# Altium schematic sheet Battery Pack_P2_REV_1.SchDoc
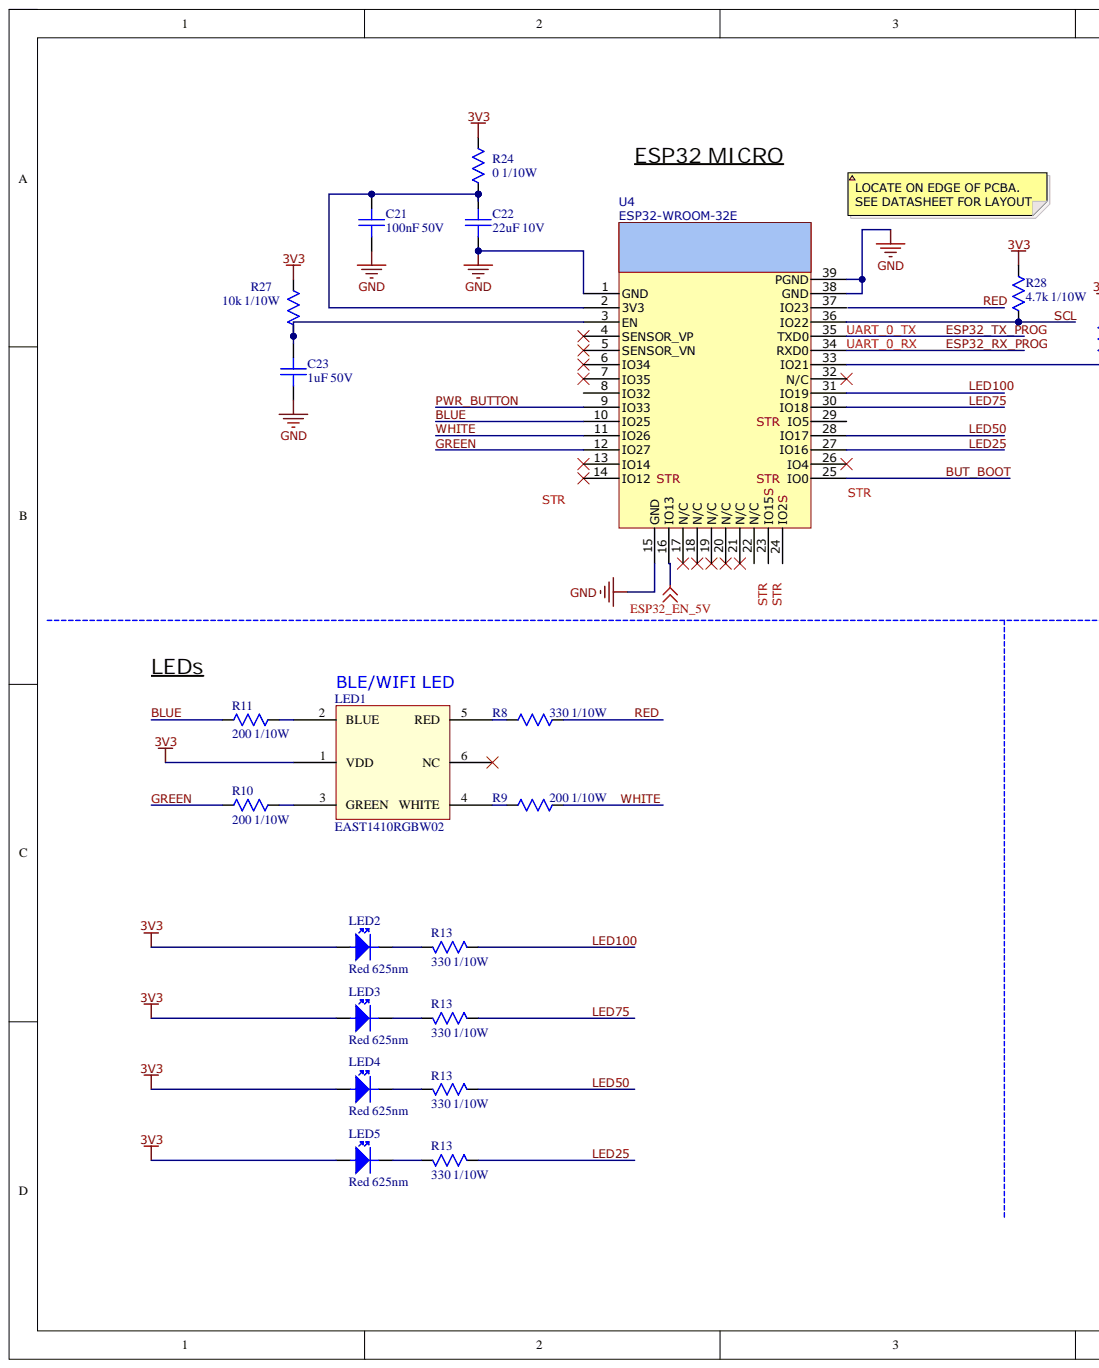
[diagram p0: Battery Pack_P2_REV_1.SchDoc, left]
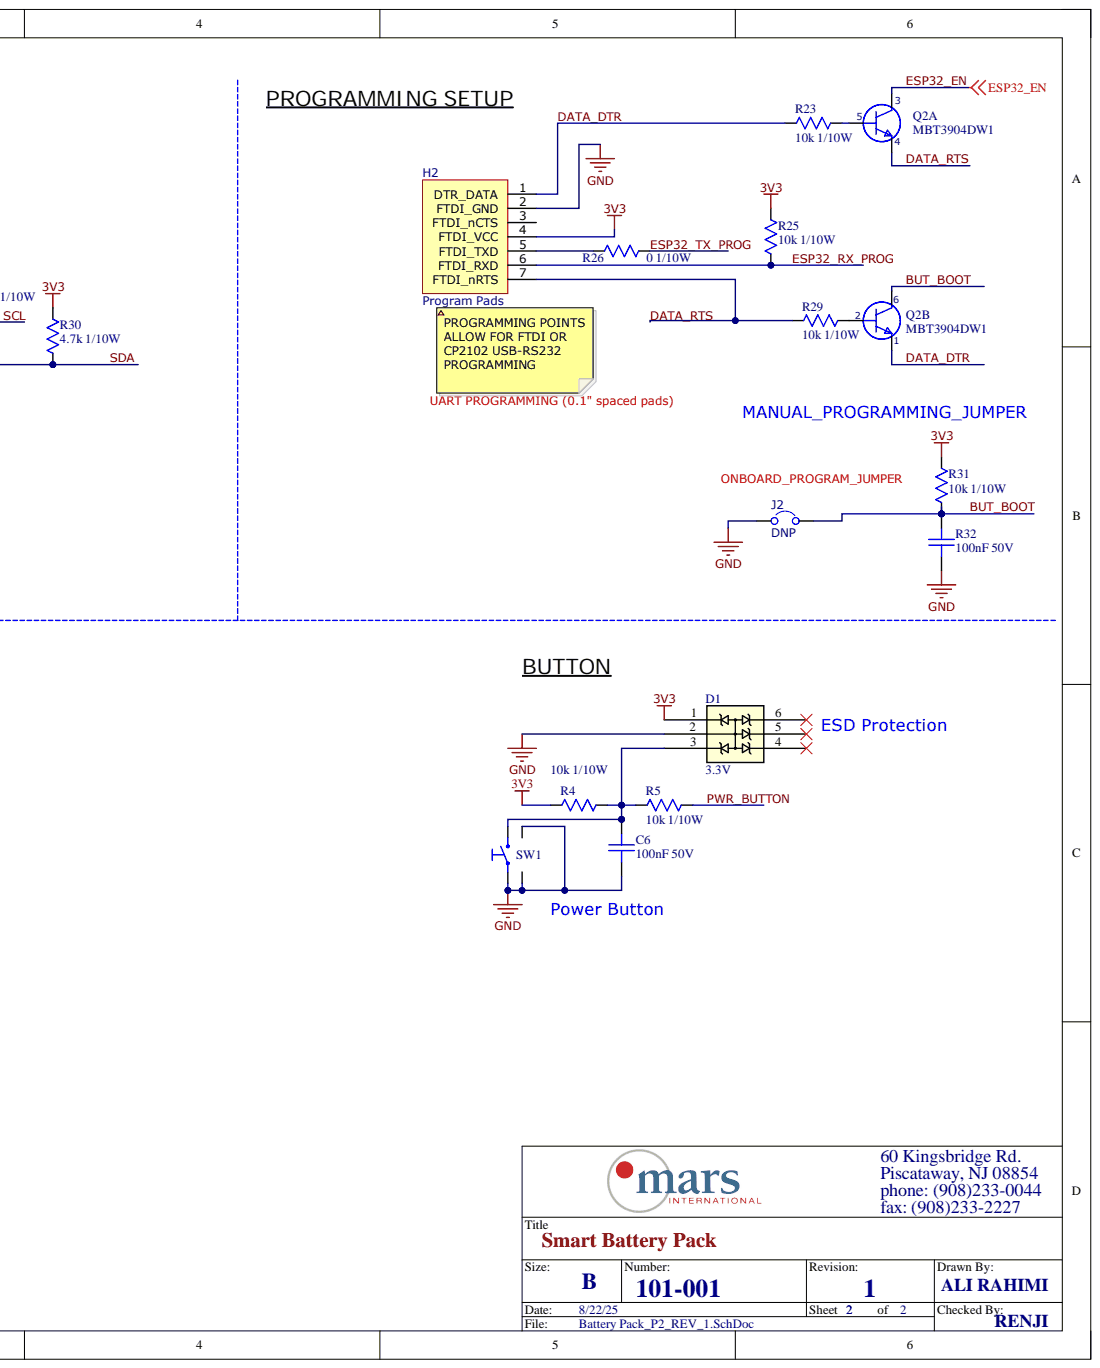
[diagram p1: Battery Pack_P2_REV_1.SchDoc, right]

## Parts
C6  100nF 50V  [IPC-0603]
C21  100nF 50V  [IPC-0603]
C22  22uF 10V  Voltage Rating: 10V  [IPC-1206]
C23  1uF 50V  Voltage Rating: 50V  [IPC-0603-Small]
D1  3.3V  [SOT363-SMALL-2NPN-123456]
H2  Program Pads  [PROGRAM-PADS-7-STRAIGHT]
J2  DNP  [CON-2]
LED1  EAST1410RGBW02  [EAST1410RGBW02]
LED2  Red 625nm  Color: Red  Wavelength: 625nm  [0603_diode]
LED3  Red 625nm  Color: Red  Wavelength: 625nm  [0603_diode]
LED4  Red 625nm  Color: Red  Wavelength: 625nm  [0603_diode]
LED5  Red 625nm  Color: Red  Wavelength: 625nm  [0603_diode]
Q2  MBT3904DW1  [SOT363-SMALL-2NPN]  (parts A,B)
R4  10k 1/10W  [IPC-0603]
R5  10k 1/10W  [IPC-0603]
R8  330 1/10W  [IPC-0603]
R9  200 1/10W  [IPC-0603]
R10  200 1/10W  [IPC-0603]
R11  200 1/10W  [IPC-0603]
R13  330 1/10W  [IPC-0603]
R13  330 1/10W  [IPC-0603]
R13  330 1/10W  [IPC-0603]
R13  330 1/10W  [IPC-0603]
R23  10k 1/10W  [IPC-0603]
R24  0 1/10W  [IPC-0603]
R25  10k 1/10W  [IPC-0603]
R26  0 1/10W  [IPC-0603]
R27  10k 1/10W  [IPC-0603]
R28  4.7k 1/10W  [IPC-0603]
R29  10k 1/10W  [IPC-0603]
R30  4.7k 1/10W  [IPC-0603]
R31  10k 1/10W  [IPC-0603]
R32  100nF 50V  [IPC-0603]
SW1  CHANNEL  [ESWITCH_TL1105VF]  (value not drawn)
U4  ESP32-WROOM-32E  [ESP32WROOM32E]

## Nets
3V3: D1.1 H2.4(FTDI_VCC) LED1.1(VDD) LED2.A LED3.A LED4.A LED5.A R4.1 R24.2 R25.2 R27.2 R28.2 R30.2 R31.2
ESP32_EN_5V: U4.16(IO13)
GND: C6.1 C21.1 C22.1 C23.1 D1.2 H2.2(FTDI_GND) J2.1 R32.1 SW1.1 SW1.3 SW1.4 U4.1(GND) U4.15(GND) U4.38(GND) U4.39(PGND)
BLUE: R11.1 U4.10(IO25)
BUT_BOOT: J2.2 Q2.6 R31.1 R32.2 U4.25(IO0)
DATA_DTR: H2.1(DTR_DATA) Q2.1 R23.2
DATA_RTS: H2.7(FTDI_nRTS) Q2.4 R29.2
ESP32_EN: Q2.3
ESP32_RX_PROG: H2.6(FTDI_RXD) R25.1 U4.34(RXD0)
ESP32_TX_PROG: R26.1 U4.35(TXD0)
GREEN: R10.1 U4.12(IO27)
LED25: R13.1 U4.27(IO16)
LED50: R13.1 U4.28(IO17)
LED75: R13.1 U4.30(IO18)
LED100: R13.1 U4.31(IO19)
PWR_BUTTON: R5.2 U4.9(IO33)
RED: R8.2
SCL: R28.1 U4.36(IO22)
SDA: R30.1 U4.33(IO21)
WHITE: R9.2 U4.11(IO26)
NetC6_2: C6.2 D1.3 R4.2 R5.1 SW1.2
NetC21_2: C21.2 C22.2 R24.1 U4.2(3V3)
NetC23_2: C23.2 R27.1 U4.3(EN)
NetH2_5: H2.5(FTDI_TXD) R26.2
NetLED1_2: LED1.2(BLUE) R11.2
NetLED1_3: LED1.3(GREEN) R10.2
NetLED1_4: LED1.4(WHITE) R9.1
NetLED1_5: LED1.5(RED) R8.1
NetLED2_K: LED2.K R13.2
NetLED3_K: LED3.K R13.2
NetLED4_K: LED4.K R13.2
NetLED5_K: LED5.K R13.2
NetQ2_2: Q2.2 R29.1
NetQ2_5: Q2.5 R23.1

Not connected: D1 4 5 6; H2 3(FTDI_nCTS); LED1 6(NC); U4 4(SENSOR_VP) 5(SENSOR_VN) 6(IO34) 7(IO35) 8(IO32) 13(IO14) 14(IO12) 17(N/C) 18(N/C) 19(N/C) 20(N/C) 21(N/C) 22(N/C) 23(IO15_S) 24(IO2_S) 26(IO4) 29(IO5) 32(N/C) 37(IO23)

## Library data of the parts
C6 = SC-0201-01: CAP CER 0.1uF 50V X7R 0603; AVL Part Numbers: GRM188R71H104KA93D, CL10B104KB8NNNC, 0603B104K500BD, CC0603KRX7R9BB104, C0603T104K5RALTU, C1608X7R104KGTS, 06035C104KAT2A; Manufacturer: AVX; Manufacturer Part Number: 06035C104KAT2A; Approved: Yes; AVL Vendors: Murata, Samsung, Team Young, Yageo, Kemet , Darfon, AVX; Database Table Name: Capacitors - Surface Mount; Dielectric Material: Ceramic; ESR: NA; Tolerance: 10%; Case/Package: 0603; Component Kind: Standard; Dielectric: X7R; Height: 0.035"; Length: 0.063"; Life(Hours): NA; Max Operating Temperature: 125°C; Min Operating Temperature: -55°C; PT Number: SC-0201-01; Ripple Current: NA; Supplier 1: Mouser; Supplier Part Number 1: 581-06035C104KAT2A; Voltage Rating: 50V; Width: 0.032"; Approved By: ADMIN; Created By: ADMIN; Library Name: MarsLibrary_Test_Environment.DbLib
C21 R32 = SC-0201-01: CAP CER 0.1uF 50V X7R 0603; AVL Part Numbers: GRM188R71H104KA93D, CL10B104KB8NNNC, 0603B104K500BD, CC0603KRX7R9BB104, C0603T104K5RALTU, C1608X7R104KGTS; Manufacturer: AVX; Manufacturer Part Number: 06035C104KAT2A; Approved: Yes; AVL Vendors: Murata, Samsung, Team Young, Yageo, Kemet , Darfon; Database Table Name: Capacitors - Surface Mount; Dielectric Material: Ceramic; ESR: NA; Tolerance: 10%; Case/Package: 0603; Component Kind: Standard; Dielectric: X7R; Height: 0.035"; Length: 0.063"; Life(Hours): NA; Max Operating Temperature: 125°C; Min Operating Temperature: -55°C; PT Number: SC-0201-01; Ripple Current: NA; Supplier 1: Mouser; Supplier Part Number 1: 581-06035C104KAT2A; Voltage Rating: 50V; Width: 0.032"; Approved By: ADMIN; Created By: ADMIN; Library Name: MarsLibrary_Test_Environment.DbLib
C22 = SC-0627-01: Cap Ceramic 22uF 10V X7R 10% SMD 1206 125C Embossed T/R; AVL Part Numbers: CL31B226KPHNNNE; Approved: Yes; AVL Vendors: Samsung; Database Table Name: Capacitors - Surface Mount; ESR: NA; Case/Package: 1206; Component Kind: Standard; Dielectric: X7R; Dielectric Material: Ceramic; Height: 0.071"; Length: 0.126"; Life(Hours): NA; Manufacturer: Samsung; Manufacturer Part Number: CL31B226KPHNNNE; Max Operating Temperature: 125°C; Min Operating Temperature: -55°C; PT Number: SC-0627-01; Ripple Current: NA; Supplier 1: Digi-Key; Supplier Part Number 1: 1276-2769-1-ND; Tolerance: 10%; Width: 0.063"; Approved By: ADMIN; Created By: ADMIN; Library Name: MarsLibrary_Test_Environment.DbLib
C23 = SC-0786-01: CAP CER 1UF 50V X5R 0603; AVL Part Numbers: UMK107BJ105KA-T, CL10A105KB8NNNC; Manufacturer: Taiyo Yuden; Manufacturer Part Number: UMK107BJ105KA-T; Approved: Yes; AVL Vendors: Taiyo Yuden, Samsung; Database Table Name: Capacitors - Surface Mount; Dielectric Material: Ceramic; ESR: NA; Tolerance: 10%; Case/Package: 0603; Component Kind: Standard; Dielectric: X5R; Height: 0.035"; Length: 0.063"; Life(Hours): NA; Max Operating Temperature: 85°C; Min Operating Temperature: -55°C; PT Number: SC-0786-01; Ripple Current: NA; Supplier 1: Digikey; Supplier Part Number 1: 587-2400-2-ND; Width: 0.031"; Approved By: ADMIN; Created By: ADMIN; Library Name: MarsLibrary_Test_Environment.DbLib
D1 = D-0101-01: TVS DIODE 3.3VWM 12VC 6TSSOP; AVL Part Numbers: PESD3V3L5UY,115; Manufacturer: Nexperia; Approved: Yes; AVL Vendors: NXP Semi; Database Table Name: Diodes - ESD; Direction: unidirectional; HBM: 10kV; IEC61000-4-4: No; Itest: 1mA; Max Breakdown Voltage: NA; Max Clamping Voltage: 12V; Max Reverse Leakage: 75nA; Min Breakdown Voltage: 5.3V; Case/Package: SOT-363; Component Kind: Standard; Height: 1.1mm; IEC61000-4-2: Yes; IEC61000-4-5: Yes; Length: 2.2mm; Manufacturer Part Number: PESD3V3L5UY,115; Max Operating Temperature: 150°C; Min Operating Temperature: -65°C; PT Number: D-0101-01; Supplier 1: Digikey; Supplier Part Number 1: 1727-3828-1-ND; Temp Coefficient: NA; Width: 2.2mm; Approved By: BKW; Created By: MAR; Library Name: MarsLibrary_Test_Environment.DbLib
H2 = ESP32_FTDI_PGM_HDR: ESP32 FTDI Programming Pads; AVL Part Numbers: NA; Approved: Yes; Database Table Name: BOM and Mechanical; AVL Vendors: NA; Case/Package: NA; Component Kind: Standard; Height: NA; Length: NA; Manufacturer: NA; Manufacturer Part Number: NA; Max Operating Temperature: NA; Min Operating Temperature: NA; PT Number: ESP32_FTDI_PGM_HDR; Supplier 1: NA; Supplier Part Number 1: NA; Width: NA; Approved By: DJS; Created By: BKW; Library Name: MarsLibrary_Test_Environment.DbLib
J2 = Jumper: PT Number: CN-0026-01; Component Kind: Standard; Library Name: 1613-003.SchLib
LED1 = LD-0198-01: LED RGBW DIFFUSED 0504 SMD; Angle: 120°; Approved: Yes; AVL Vendors: Everlight; Database Table Name: Diodes - LEDs; Forward Voltage: 2.7V; Intensity: 3mcd Red, 25mcd Green, 5mcd Blue, 10mcd White; Itest: 10mA; Lens Color/Type: White; Type: DEV; Vr Max: 1.75V Red, 2.4V Green, 2.7V Blue, 2.7V White; Wavelength: 622nm Red, 531nm Green, 471nm Blue, 5000k White; AVL Part Numbers: EAST1410RGBW02; Case/Package: 0504; Color: RGBW; Component Kind: Standard; Height: 0.75mm; Lead Spacing: 0.5mm; Length: 1.45mm; Manufacturer: Everlight; Manufacturer Part Number: EAST1410RGBW02; Manufacturer_Part_Number: EAST1410RGBW02; Max Operating Temperature: 85°C; Mfr_Name: Everlight; Min Operating Temperature: -40°C; PT Number: LD-0198-01; RefDes: RefDes; ROHS: No; Supplier 1: Digikey; Supplier Part Number 1: 1080-EAST1410RGBW02CT-ND; Temperature: 5000k; Width: 1mm; Approved By: BKW; Copyright: Copyright (C) 2024 Ultra Librarian. All rights reserved.; Created By: MAR; Library Name: MarsLibrary_Test_Environment.DbLib
LED2-LED5 = LD-0199-01: LED RED CLEAR 0603 SMD; AVL Part Numbers: 150060RS75000; Angle: 140°; Approved: No; AVL Vendors: Wurth; Database Table Name: Diodes - LEDs; Forward Voltage: 2V; Intensity: 250mcd @20mA; Itest: 20mA; Lens Color/Type: Colorless Clear; Vr Max: 5V; Case/Package: 0603; Component Kind: Standard; Height: 0.8mm; Lead Spacing: NA; Length: 1.6mm; Manufacturer: Wurth; Manufacturer Part Number: 150060RS75000; Max Operating Temperature: 85°C; Min Operating Temperature: -40°C; PT Number: LD-0199-01; ROHS: Yes; Supplier 1: Digikey; Supplier Part Number 1: 732-4978-1-ND; Temperature: NA; Width: 0.8mm; Created By: MAR; Library Name: MarsLibrary_Test_Environment.DbLib
Q2 = T-0213-01: TRANS NPN DUAL 40V 0.2A; Manufacturer: ON Semiconductor; Approved: Yes; AVL Vendors: On Semi; Collector Emitter Voltage (VCEO): 200mA; CTR MAX: 100; CTR MIN: 300mV; Database Table Name: Transistors - Bipolar; Photodiode Direction: 60V; Photodiode IF Max: 6V; UL Isolation Voltage: 150mW; AVL Part Numbers: MBT3904DW1; Case/Package: SOT-363; Component Kind: Standard; Height: 1.1mm; Length: 2.2mm; Manufacturer Part Number: MBT3904DW1; Max Collector Current: 200mA; Max Operating Temperature: 150°C; Min Operating Temperature: -55°C; Photodiode Reverse Voltage MAX: 40V; PT Number: T-0213-01; RoHS: Yes; Supplier 1: Digikey; Supplier Part Number 1: MBT3904DW1T1GOSTR-ND; Width: 2.2mm; Approved By: ADMIN; Created By: ADMIN; Library Name: MarsLibrary_Test_Environment.DbLib
R4 R5 R23 R25 R27 R29 R31 = R-0104-01: RES SMD 10K OHM 5% 1/10W 0603; AVL Part Numbers: RCT03JT103, ERJ-3GEYJ103V, RC0603JR-0710K, RC0603JR-0710KL, CR10-103J-T, RM06-103JT, RCT0310KJLF; Manufacturer: Yageo; Manufacturer Part Number: RC0603JR-0710KL; Approved: Yes; Array: NA; AVL Vendors: Hong Kong Resistor, Panasonic, Yageo, Yageo, AVX, Fu Da, HK Resistor; Database Table Name: Resistors; Power Rating: 100mW; Temperature Coefficient: ±100ppm/°; Voltage Rating: 75V; Case/Package: 0603; Component Kind: Standard; Composition: Thick Film; Height: 0.031"; Length: 0.063"; Max Operating Temperature: 155°C; Min Operating Temperature: -55°C; PT Number: R-0104-01; RoHS: Yes; Supplier 1: Digikey; Supplier Part Number 1: 311-10KGRCT-ND; Tolerance: 5%; Width: 0.031"; Approved By: ADMIN; Created By: ADMIN; Library Name: MarsLibrary_Test_Environment.DbLib
R8 R13 R13 R13 R13 = R-0105-01: RES SMD 330 OHM 5% 1/10W 0603; AVL Part Numbers: CR10-331J-T, RCT03JT331, RK73B1JLTD331J, ERJ-3GEYJ331V, RC0603JR-07330R, RC0603JR-07330RL, RCT03330RJLF; Manufacturer: Panasonic; Manufacturer Part Number: ERJ-3GEYJ331V; Approved: Yes; Array: NA; AVL Vendors: AVX, Hong Kong Resistor, KOA, Panasonic, Yageo, Yageo, HK Resistor; Database Table Name: Resistors; Power Rating: 100mW; Temperature Coefficient: ±200ppm/°C; Voltage Rating: 75V; Case/Package: 0603; Component Kind: Standard; Composition: Thick Film; Height: 0.018"; Length: 0.063"; Max Operating Temperature: 155°C; Min Operating Temperature: -55°C; PT Number: R-0105-01; RoHS: Yes; Supplier 1: Digikey; Supplier Part Number 1: P330GCT-ND; Tolerance: 5%; Width: 0.031"; Approved By: ADMIN; Created By: ADMIN; Library Name: MarsLibrary_Test_Environment.DbLib
R9-R11 = R-0173-01: RES SMD 200 OHM 5% 1/10W 0603; AVL Part Numbers: RCT03200RJLF, RC0603JR-07200RL; Manufacturer: Yageo; Manufacturer Part Number: RC0603JR-07200RL; Approved: Yes; Array: NA; AVL Vendors: Hong Kong Resistor, YAGEO; Database Table Name: Resistors; Power Rating: 100mW; Temperature Coefficient: ±100ppm/°C; Case/Package: 0603; Component Kind: Standard; Composition: Thick Film; Height: 0.022"; Length: 0.063"; Max Operating Temperature: 155°C; Min Operating Temperature: -55°C; PT Number: R-0173-01; RoHS: Yes; Supplier 1: Digikey; Supplier Part Number 1: 311-200GRCT-ND; Tolerance: 5%; Width: 0.031"; Approved By: ADMIN; Created By: ADMIN; Library Name: MarsLibrary_Test_Environment.DbLib
R24 R26 = R-0103-01: RES SMD 0 OHM 5% 1/10W 0603; AVL Part Numbers: RCT030R0J, ERJ-3GEY0R00V, MCR03EZPJ000, RC0603JR-070RL, RCT030RLF; Manufacturer: Panasonic; Manufacturer Part Number: ERJ-3GEY0R00V; Approved: Yes; Array: NA; AVL Vendors: HK Resistor, Panasonic, Rohm, Yageo, HK Resistor; Database Table Name: Resistors; Power Rating: 100mW; Temperature Coefficient: ±100ppm/°C; Voltage Rating: 75V; Case/Package: 0603; Component Kind: Standard; Composition: Thick Film; Height: 0.018"; Length: 0.063"; Max Operating Temperature: 155°C; Min Operating Temperature: -55°C; PT Number: R-0103-01; RoHS: Yes; Supplier 1: Digikey; Supplier Part Number 1: P0.0GCT-ND; Tolerance: 5%; Width: 0.031"; Approved By: ADMIN; Created By: ADMIN; Library Name: MarsLibrary_Test_Environment.DbLib
R28 R30 = R-0134-01: RES SMD 4.7K OHM 5% 1/10W 0603; Manufacturer: Yageo; Manufacturer Part Number: RC0603JR-074K7L; Approved: Yes; Array: NA; Database Table Name: Resistors; Power Rating: 100mW; Temperature Coefficient: ±100ppm/°C; Voltage Rating: 75V; Case/Package: 0603; Component Kind: Standard; Composition: Thick Film; Height: 0.022"; Length: 0.063"; Max Operating Temperature: 155°C; Min Operating Temperature: -55°C; PT Number: R-0134-01; RoHS: Yes; Supplier 1: Digikey; Supplier Part Number 1: 311-4.7KGRTR-ND; Tolerance: 5%; Width: 0.031"; Approved By: ADMIN; Created By: ADMIN; Library Name: MarsLibrary_Test_Environment.DbLib
SW1 = SW-0278-01: SWITCH TACTILE SPST-NO 0.05A 12V; Manufacturer: E-Switch; Manufacturer Part Number: TL1105VF250Q; Mfr_Name: TE Connectivity; Action: PUSH; Approved: No; Current (AC): NA; Current (DC): 50mA; Database Table Name: Switches; Form: A; Case/Package: RA TH 7MM; Component Kind: Standard; Height: 3.85 mm; Illumination: No; Length: 6mm; Max Operating Temperature: 70°C; Min Operating Temperature: -20°C; PT Number: SW-0278-01; RoHs: Yes; Supplier 1: Digi-Key; Supplier Part Number 1: EG2509-ND  EG2507-ND; Type: Tactile; Voltage (AC): NA; Voltage (DC): 12V; Width: 6mm; Created By: AW; Library Name: MarsLibrary_Test_Environment.DbLib
U4 = U-0952-01: IC RADIO MODULE ESP32 SMT; AVL Part Numbers: ESP32-WROOM-32E-N16, ESP32-WROOM-32E-N8; Manufacturer: Espressif; Manufacturer Part Number: ESP32-WROOM-32E-N8; Approved: No; AVL Vendors: Espressif, Espressif; Database Table Name: Integrated Circuits; Interface: I2C, I2S, PWM, SDIO, SPI, UART; Max Supply Voltage: 3.6V; Min Supply Voltage: 3.0V; Case/Package: SMT; Component Kind: Standard; Height: 3.1mm; Length: 25.5mm; Max Operating Temperature: 85°C; Min Operating Temperature: -40°C; Part Type: Radio Module; PT Number: U-0952-01; RoHS: Yes; Supplier 1: Digikey; Supplier Part Number 1: 1965-ESP32-WROOM-32E-N8TR-ND; Width: 18.0mm; Created By: AW; Library Name: MarsLibrary_Test_Environment.DbLib
